# Altium schematic sheet ControlBox.SchDoc
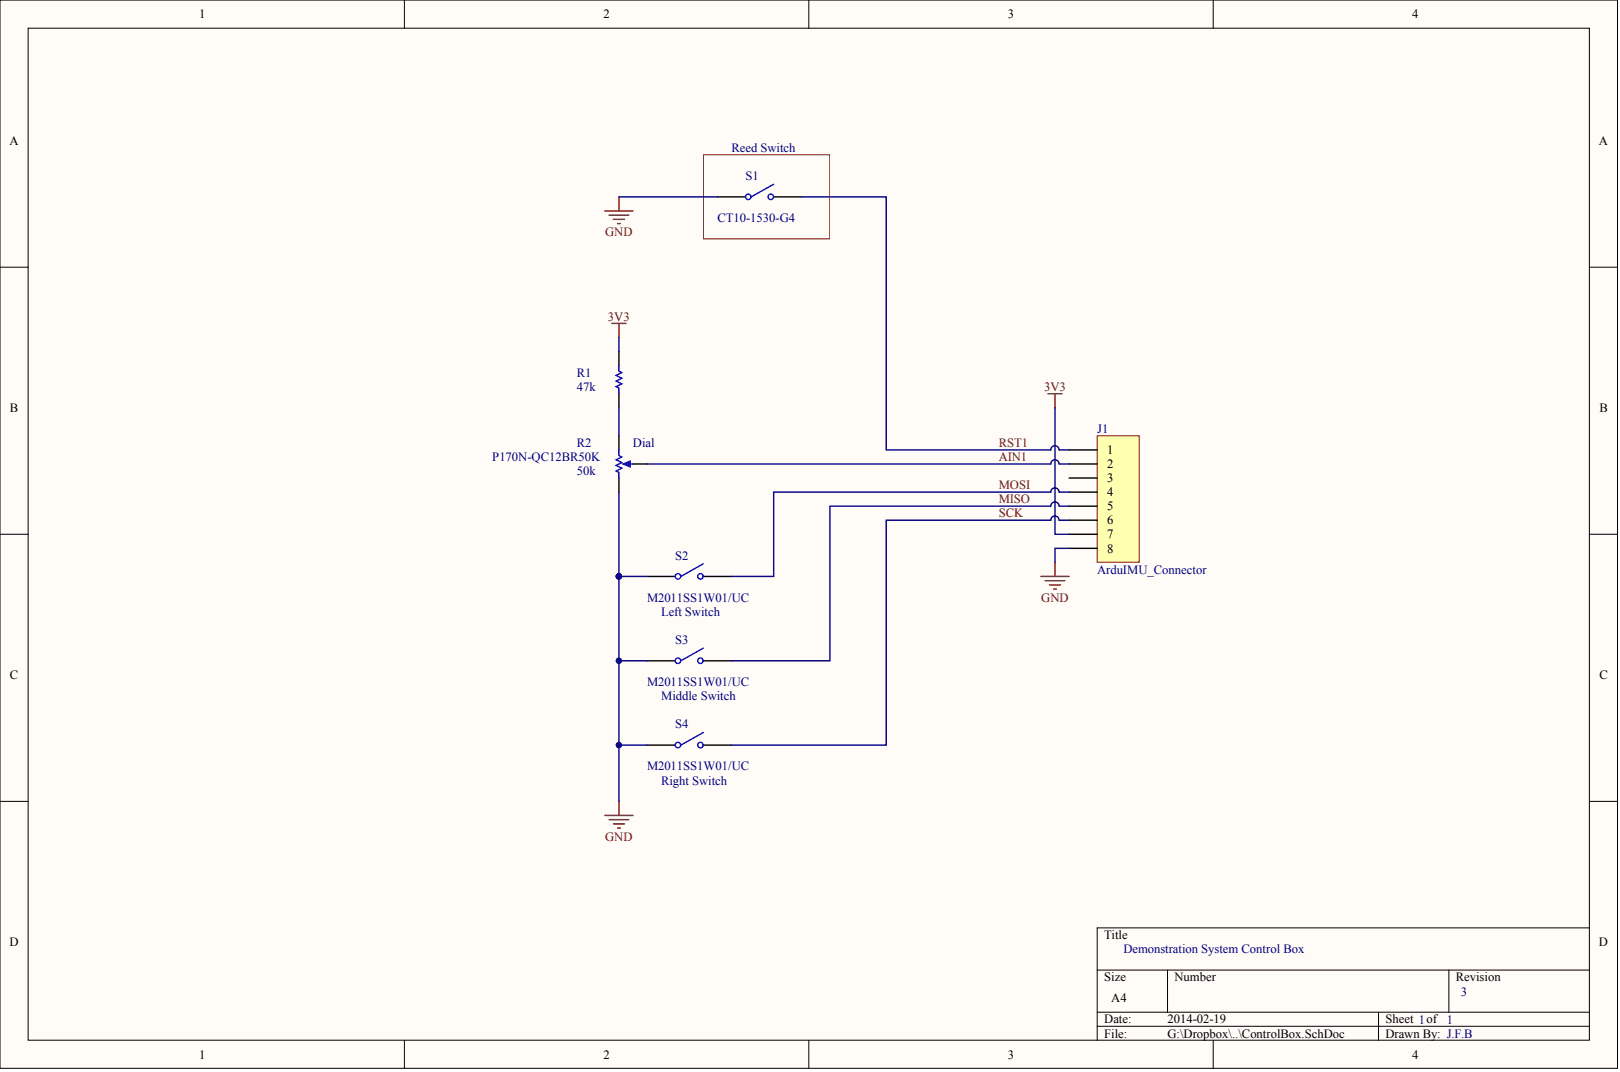
[diagram p0: ControlBox.SchDoc]

## Parts
J1  ArduIMU_Connector
R1  value 47k
R2  P170N-QC12BR50K  value 50k
S1  CT10-1530-G4
S2  M2011SS1W01/UC
S3  M2011SS1W01/UC
S4  M2011SS1W01/UC

## Nets
3V3: J1.7 R1.2
GND: J1.8 R2.1 S1.1 S2.1 S3.1 S4.1
AIN1: J1.2 R2.3
MISO: J1.5 S3.2
MOSI: J1.4 S2.2
RST1: J1.1 S1.2
SCK: J1.6 S4.2
NetR1_1: R1.1 R2.2

Not connected: J1 3

## Library data of the parts
R2 = (no symbol name): Sim Note: Enter the Resistance value and the set point into parameter fields.; Set Position: 0.5; Published: 8-Jun-2000; LatestRevisionDate: 17-Jul-2002; LatestRevisionNote: Re-released for DXP Platform.; PackageReference: VR5; PackageDescription: Variable Resistor, Thru-Hole; 3 Leads; Publisher: Altium Limited
J1 R1 S1-S4 = (no symbol name): LatestRevisionDate: 17-Jul-2002; LatestRevisionNote: Re-released for DXP Platform.; Publisher: Altium Limited
  R1: Published: 8-Jun-2000; PackageReference: AXIAL-0.3; PackageDescription: Axial Device, Thru-Hole; 2 Leads; 0.3 in Pin Spacing
  S1-S4: Published: 24-Mar-1999; PackageReference: SPST-2; PackageDescription: Switch; 2 Leads
